# Altium schematic sheet MCU.SchDoc
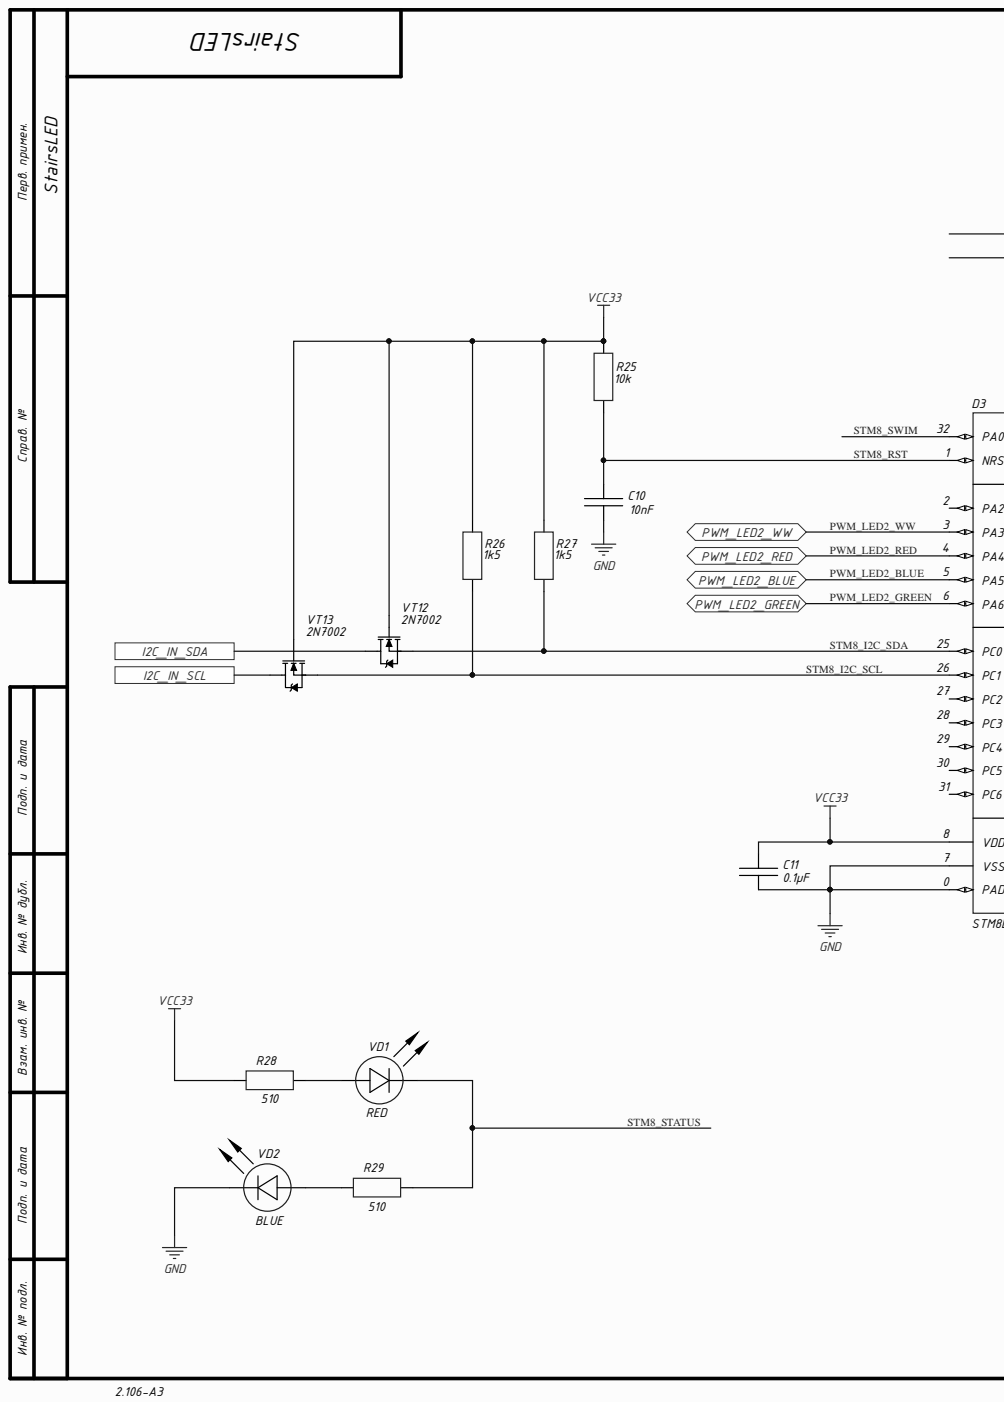
[diagram p0: MCU.SchDoc, left]
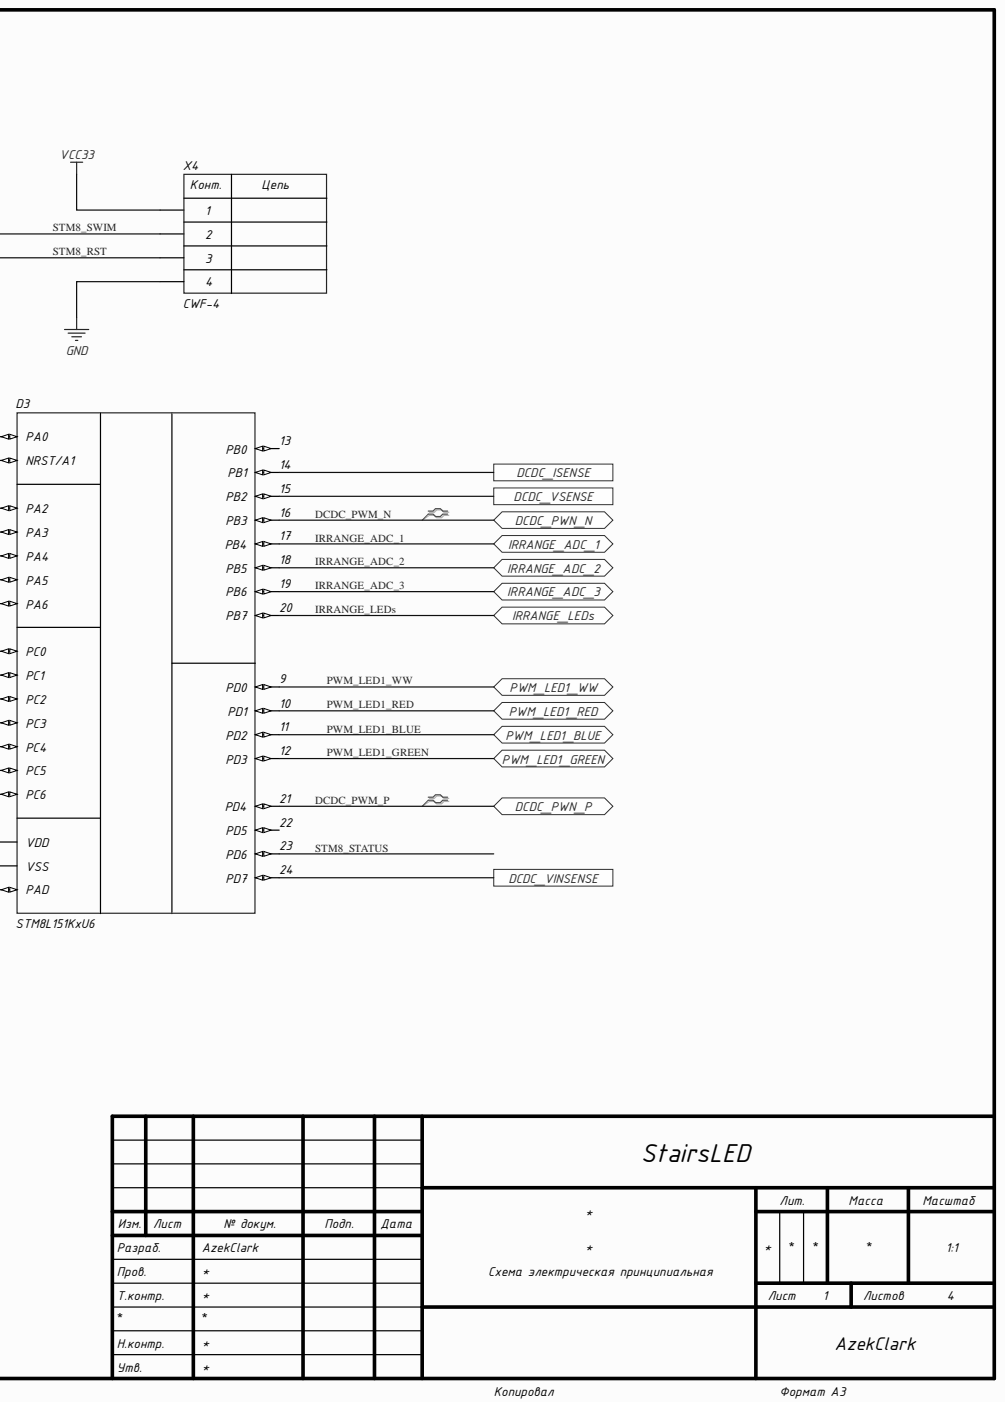
[diagram p1: MCU.SchDoc, right]

## Parts
C10  10nF  [CH0603C]
C11  0.1µF  [CH0603C]
D3  STM8L151KxU6  [32-UFQFPN]
R25  10k  [CH0603R]
R26  1k5  [CH0603R]
R27  1k5  [CH0603R]
R28  510  [CH0603R]
R29  510  [CH0603R]
VD1  RED  [CH0603LR]
VD2  BLUE  [CH0603LB]
VT12  2N7002  [SOT23_132]
VT13  2N7002  [SOT23_132]
X4  CWF-4  [CON: CWF-4]

## Ports
DCDC_ISENSE, DCDC_PWN_N, DCDC_PWN_P, DCDC_VINSENSE, DCDC_VSENSE, I2C_IN_SCL, I2C_IN_SDA, IRRANGE_ADC_1, IRRANGE_ADC_2, IRRANGE_ADC_3, IRRANGE_LEDs, PWM_LED1_BLUE, PWM_LED1_GREEN, PWM_LED1_RED, PWM_LED1_WW, PWM_LED2_BLUE, PWM_LED2_GREEN, PWM_LED2_RED, PWM_LED2_WW

## Nets
GND: C10.1 C11.2 D3.0(PAD) D3.7(VSS) VD2.2 X4.4
VCC33: C11.1 D3.8(VDD) R25.2 R26.2 R27.2 R28.2 VT12.1 VT13.1 X4.1
DCDC_ISENSE: D3.14(PB1) ; port DCDC_ISENSE
DCDC_PWM_N: D3.16(PB3) ; port DCDC_PWN_N
DCDC_PWM_P: D3.21(PD4) ; port DCDC_PWN_P
DCDC_VINSENSE: D3.24(PD7) ; port DCDC_VINSENSE
DCDC_VSENSE: D3.15(PB2) ; port DCDC_VSENSE
I2C_IN_SCL: VT13.2 ; port I2C_IN_SCL
I2C_IN_SDA: VT12.2 ; port I2C_IN_SDA
IRRANGE_ADC_1: D3.17(PB4) ; port IRRANGE_ADC_1
IRRANGE_ADC_2: D3.18(PB5) ; port IRRANGE_ADC_2
IRRANGE_ADC_3: D3.19(PB6) ; port IRRANGE_ADC_3
IRRANGE_LEDs: D3.20(PB7) ; port IRRANGE_LEDs
PWM_LED1_BLUE: D3.11(PD2) ; port PWM_LED1_BLUE
PWM_LED1_GREEN: D3.12(PD3) ; port PWM_LED1_GREEN
PWM_LED1_RED: D3.10(PD1) ; port PWM_LED1_RED
PWM_LED1_WW: D3.9(PD0) ; port PWM_LED1_WW
PWM_LED2_BLUE: D3.5(PA5) ; port PWM_LED2_BLUE
PWM_LED2_GREEN: D3.6(PA6) ; port PWM_LED2_GREEN
PWM_LED2_RED: D3.4(PA4) ; port PWM_LED2_RED
PWM_LED2_WW: D3.3(PA3) ; port PWM_LED2_WW
STM8_I2C_SCL: D3.26(PC1) R26.1 VT13.3
STM8_I2C_SDA: D3.25(PC0) R27.1 VT12.3
STM8_RST: C10.2 D3.1(NRST/A1) R25.1 X4.3
STM8_STATUS: D3.23(PD6) R29.1 VD1.2
STM8_SWIM: D3.32(PA0) X4.2
NetR28_1: R28.1 VD1.1
NetR29_2: R29.2 VD2.1

Not connected: D3 2(PA2) 13(PB0) 22(PD5) 27(PC2) 28(PC3) 29(PC4) 30(PC5) 31(PC6)

## Library data of the parts
C10 C11 = Capacitor: Component Kind: Standard; Library Name: Discrete.IntLib
  C10: Value: 10nF
  C11: Value: 0.1µF
D3 = STM8L151KxU6: STM8; Manufacturer: ST Microelectronics; Model: STM8L151GxU6; Component Kind: Standard; ComponentKind: UFQFPN28; HelpURL: https://ru.mouser.com/datasheet/2/389/stm8l151c4-956418.pdf; Library Name: Digital.IntLib
R25-R29 = Resistor: Component Kind: Standard; Library Name: Discrete.IntLib
  R25: Value: 10k
  R26 R27: Value: 1k5
  R28 R29: Value: 510
VD1 VD2 = LED: Component Kind: Standard; Library Name: Discrete.IntLib
  VD1: Value: RED
  VD2: Value: BLUE
VT12 VT13 = N-chanel: Mosfet; Manufacturer: ON Semiconductor; Component Kind: Standard; ComponentKind: 0.2А, 60В; HelpURL: https://static.chipdip.ru/lib/318/DOC000318735.pdf; Library Name: Transistor.IntLib; Model: 2N7002
X4 = 4-Connector: Component Kind: Standard; Library Name: Connectors.IntLib; Model: CWF-4
